# Altium schematic sheet ST-Link-V2.2.SchDoc
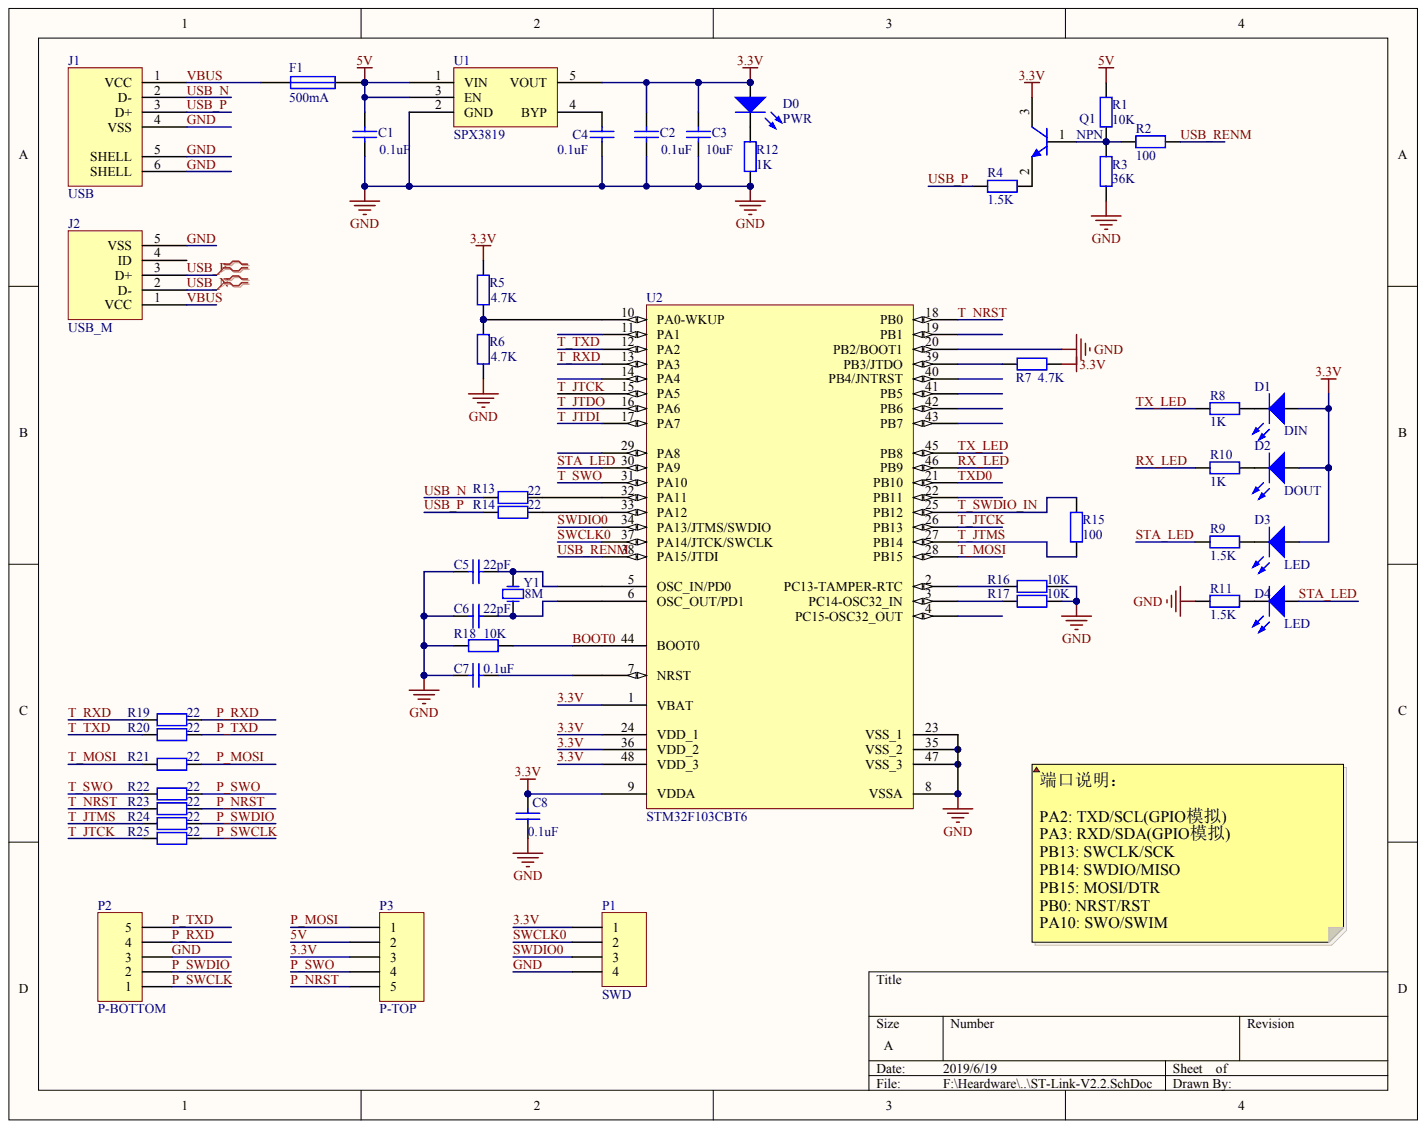
[diagram p0: ST-Link-V2.2.SchDoc]

## Parts
C1  value 0.1uF  (hidden comment: Cap)
C2  value 0.1uF  (hidden comment: Cap)
C3  value 10uF  (hidden comment: Cap)
C4  value 0.1uF  (hidden comment: Cap)
C5  value 22pF  (hidden comment: Cap)
C6  value 22pF  (hidden comment: Cap)
C7  value 0.1uF  (hidden comment: Cap)
C8  value 0.1uF  (hidden comment: Cap)
D0  PWR
D1  DIN
D2  DOUT
D3  LED
D4  LED
F1  value 500mA  (hidden comment: Fuse1)
J1  USB
J2  USB_M
P1  SWD
P2  P-BOTTOM
P3  P-TOP
Q1  NPN
R1  value 10K  (hidden comment: Res1)
R2  value 100  (hidden comment: Res1)
R3  value 36K  (hidden comment: Res1)
R4  value 1.5K  (hidden comment: Res1)
R5  value 4.7K  (hidden comment: Res2)
R6  value 4.7K  (hidden comment: Res2)
R7  value 4.7K  (hidden comment: Res2)
R8  value 1K  (hidden comment: Res2)
R9  value 1.5K  (hidden comment: Res2)
R10  value 1K  (hidden comment: Res2)
R11  value 1.5K  (hidden comment: Res2)
R12  value 1K  (hidden comment: Res2)
R13  value 22  (hidden comment: Res2)
R14  value 22  (hidden comment: Res2)
R15  value 100  (hidden comment: Res2)
R16  value 10K  (hidden comment: Res1)
R17  value 10K  (hidden comment: Res1)
R18  value 10K  (hidden comment: Res2)
R19  value 22  (hidden comment: Res2)
R20  value 22  (hidden comment: Res2)
R21  value 22  (hidden comment: Res2)
R22  value 22  (hidden comment: Res2)
R23  value 22  (hidden comment: Res2)
R24  value 22  (hidden comment: Res2)
R25  value 22  (hidden comment: Res2)
U1  SPX3819
U2  STM32F103CBT6
Y1  8M

## Nets
3.3V: C2.2 C3.2 C8.2 D0.1 D1.1 D2.1 D3.1 P1.1 P3.3 Q1.3 R5.2 R7.2 U1.5(VOUT) U2.1(VBAT) U2.9(VDDA) U2.24(VDD_1) U2.36(VDD_2) U2.48(VDD_3)
5V: C1.2 F1.2 P3.2 R1.1 U1.1(VIN) U1.3(EN)
BOOT0: R18.2 U2.44(BOOT0)
GND: C1.1 C2.1 C3.1 C4.1 C5.1 C6.1 C7.1 C8.1 J1.4(VSS) J1.5(SHELL) J1.6(SHELL) J2.5(VSS) P1.4 P2.3 R3.2 R6.1 R11.1 R12.1 R16.2 R17.2 R18.1 U1.2(GND) U2.8(VSSA) U2.20(PB2/BOOT1) U2.23(VSS_1) U2.35(VSS_2) U2.47(VSS_3)
P_MOSI: P3.1 R21.2
P_NRST: P3.5 R23.2
P_RXD: P2.4 R19.2
P_SWCLK: P2.1 R25.2
P_SWDIO: P2.2 R24.2
P_SWO: P3.4 R22.2
P_TXD: P2.5 R20.2
RX_LED: R10.1 U2.46(PB9)
STA_LED: D4.1 R9.2 U2.30(PA9)
SWCLK0: P1.2 U2.37(PA14/JTCK/SWCLK)
SWDIO0: P1.3 U2.34(PA13/JTMS/SWDIO)
T_JTCK: R25.1 U2.15(PA5) U2.26(PB13)
T_JTDI: U2.17(PA7)
T_JTDO: U2.16(PA6)
T_JTMS: R15.1 R24.1 U2.27(PB14)
T_MOSI: R21.1 U2.28(PB15)
T_NRST: R23.1 U2.18(PB0)
T_RXD: R19.1 U2.13(PA3)
T_SWDIO_IN: R15.2 U2.25(PB12)
T_SWO: R22.1 U2.31(PA10)
T_TXD: R20.1 U2.12(PA2)
TX_LED: R8.1 U2.45(PB8)
TXD0: U2.21(PB10)
USB_N: J1.2(D-) J2.2(D-) R13.1
USB_P: J1.3(D+) J2.3(D+) R4.2 R14.1
USB_RENM: R2.2 U2.38(PA15/JTDI)
VBUS: F1.1 J1.1(VCC) J2.1(VCC)
NetC4_2: C4.2 U1.4(BYP)
NetC5_2: C5.2 U2.5(OSC_IN/PD0) Y1.1
NetC6_2: C6.2 U2.6(OSC_OUT/PD1) Y1.2
NetC7_2: C7.2 U2.7(NRST)
NetD0_2: D0.2 R12.2
NetD1_2: D1.2 R8.2
NetD2_2: D2.2 R10.2
NetD3_2: D3.2 R9.1
NetD4_2: D4.2 R11.2
NetQ1_1: Q1.1 R1.2 R2.1 R3.1
NetQ1_2: Q1.2 R4.1
NetR5_1: R5.1 R6.2 U2.10(PA0-WKUP)
NetR7_1: R7.1 U2.39(PB3/JTDO)
NetR13_2: R13.2 U2.32(PA11)
NetR14_2: R14.2 U2.33(PA12)
NetR16_1: R16.1 U2.2(PC13-TAMPER-RTC)
NetR17_1: R17.1 U2.3(PC14-OSC32_IN)

Not connected: J2 4(ID); U2 4(PC15-OSC32_OUT) 11(PA1) 14(PA4) 19(PB1) 22(PB11) 29(PA8) 40(PB4/JNTRST) 41(PB5) 42(PB6) 43(PB7)

## Library data of the parts
Y1 = (no symbol name): Manufacturer: Generic Components; Class: Oscillator; SubClass: Crystal Oscillator; Published: 08/06/2000; Publisher: Altium Hobart Technology Centre
C1-C8 D0-D4 F1 J1 J2 P1-P3 Q1 R1-R25 U1 U2 = (no symbol name)
  C1-C4 C8: Published: 8-Jun-2000; LatestRevisionDate: 17-Jul-2002; LatestRevisionNote: Re-released for DXP Platform.; PackageReference: RAD-0.3; PackageDescription: Radial Cap, Thru-Hole; 2 Leads; 0.3 in Pin Spacing
  D0-D4: Published: 8-Jun-2000; LatestRevisionDate: 17-Jul-2002; LatestRevisionNote: Re-released for DXP Platform.; PackageVersion: Feb-2002; PackageReference: 3.2X1.6X1.1; PackageDescription: SM LED; 2 Flat Leads
  P1-P3: LatestRevisionDate: 17-Jul-2002; LatestRevisionNote: Re-released for DXP Platform.
  Q1: Published: 8-Jun-2000; LatestRevisionDate: 15-Jan-2003; LatestRevisionNote: PCB Footprint 'BCY-W3/D4.7' replaced; DatasheetDocument: 1997; PackageDocument: Sep-1998; PackageReference: TO-92A; Code_JEDEC: TO-92A; ComponentLink1Description: Manufacturer Link; ComponentLink1URL: http://www.fairchildsemi.com/; ComponentLink2Description: Datasheet; ComponentLink2URL: http://www.fairchildsemi.com/ds/2N%2F2N3904.pdf
  R1-R4 R16 R17: Published: 8-Jun-2000; LatestRevisionDate: 17-Jul-2002; LatestRevisionNote: Re-released for DXP Platform.; PackageReference: AXIAL-0.4
  R5-R15 R18-R25: Published: 8-Jun-2000; LatestRevisionDate: 17-Jul-2002; LatestRevisionNote: Re-released for DXP Platform.; PackageReference: AXIAL-0.4; PackageDescription: Axial Device, Thru-Hole; 2 Leads; 0.4 in Pin Spacing
  U2: Published: 30-May-2008; DatasheetVersion: rev6, Mar-2008; PackageVersion: Mar-2008; PackageReference: LQFP48; PackageDescription: 48-Pin Low-Profile Quad Flat Pack, 7 x 7 mm Body, 0.5 mm Pitch (LQFP48); ComponentLink1Description: Manufacturer Link; ComponentLink1URL: www.st.com/stm32; ComponentLink2Description: Datasheet; ComponentLink2URL: http://www.st.com/stonline/products/literature/ds/13587.pdf
  C1-C4 C8 D0-D4 P1-P3 Q1 R1-R25 U2: Publisher: Altium Limited
